# Altium schematic sheet eBox_relay.SchDoc
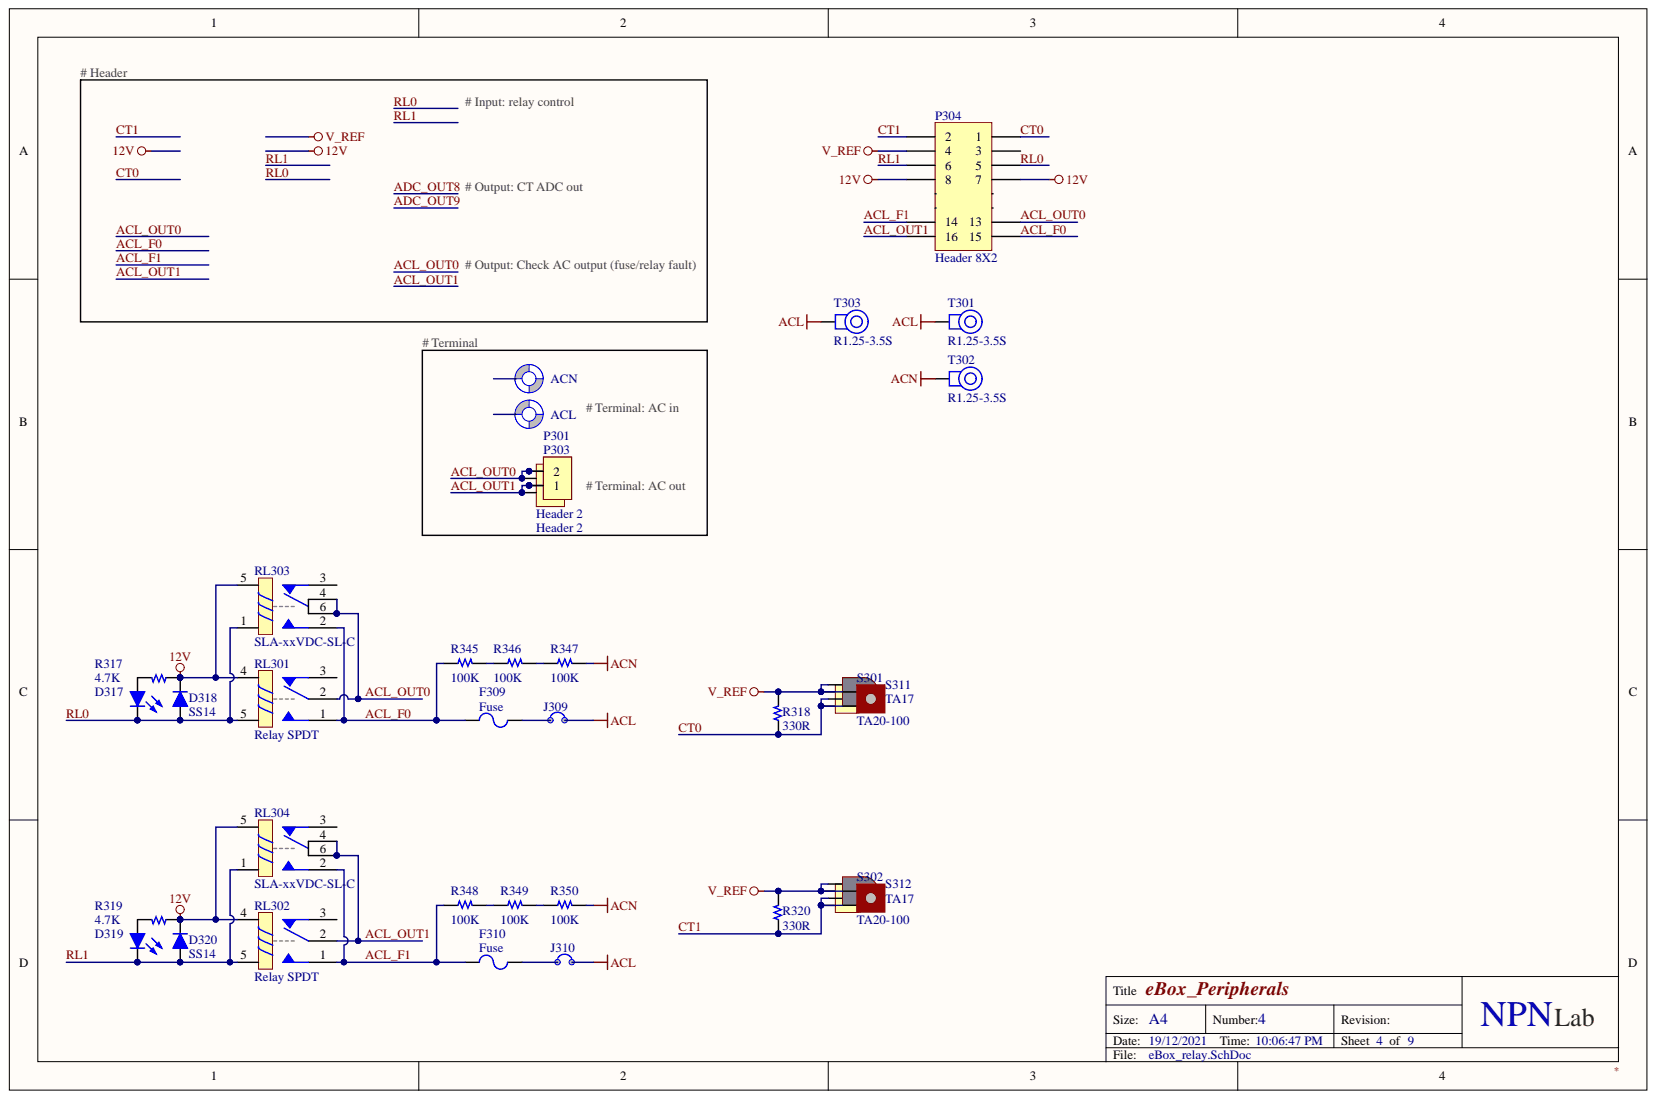
[diagram p0: eBox_relay.SchDoc]

## Parts
ACL  Screw Hole  [SCREW/3.2/7.0/M]  (value not drawn)  (no BOM)
ACN  Screw Hole  [SCREW/3.2/7.0/M]  (value not drawn)  (no BOM)
D317  Led  [LED 3MM - green]  (value not drawn)
D318  SS14  [DO-214AC (SMA) L]
D319  Led  [LED 3MM - green]  (value not drawn)
D320  SS14  [DO-214AC (SMA) L]
F309  Fuse  [FUSE 2 DIP 0520 BOX]
F310  Fuse  [FUSE 2 DIP 0520 BOX]
J309  JUMP 2 CUSTOM  [JUMP/2/Custom]  (value not drawn)  (no BOM)
J310  JUMP 2 CUSTOM  [JUMP/2/Custom]  (value not drawn)  (no BOM)
P301  Header 2  [HDR/Terminal/2/KF1000]  (no BOM)
P303  Header 2  [HDR/Terminal/2/KF55C-10]
P304  Header 8X2  [HDR/Header/16/DL/EDGE]
P305  Header 2  [HDR/Terminal/2/KF1000]  (no BOM)
R317  4.7K  [R 0603]
R318  330R  [R 0603]
R319  4.7K  [R 0603]
R320  330R  [R 0603]
R345  100K  [R 1206]
R346  100K  [R 1206]
R347  100K  [R 1206]
R348  100K  [R 1206]
R349  100K  [R 1206]
R350  100K  [R 1206]
RL301  Relay SPDT  [RL_SPDT_MIX]
RL302  Relay SPDT  [RL_SPDT_MIX]
RL303  SLA-xxVDC-SL-C  [RL_SPDT_30A]
RL304  SLA-xxVDC-SL-C  [RL_SPDT_30A]
S301  TA20-100  [TA20-100]
S302  TA20-100  [TA20-100]
S309  TA12-200  [TA12]  (value not drawn)
S310  NA  [TA12]  (value not drawn)
S311  TA17  [TA17]
S312  TA17  [TA17]
T301  R1.25-3.5S  [R1.25-3.5S]
T302  R1.25-3.5S  [R1.25-3.5S]
T303  R1.25-3.5S  [R1.25-3.5S]

## Nets
12V: D318.2 D320.2 P304.7 P304.8 R317.1 R319.1 RL301.4 RL302.4 RL303.5 RL304.5
ACL: J309.2 J310.2 T301.1 T303.1
ACN: R347.2 R350.2 T302.1
V_REF: P304.4 R318.2 R320.2 S301.3 S302.3 S309.1 S310.1 S311.1 S312.1
ACL_F0: F309.1 P304.15 R345.1 RL301.1 RL303.2
ACL_F1: F310.1 P304.14 R348.1 RL302.1 RL304.2
ACL_OUT0: P301.1 P303.1 P304.13 P305.2 RL301.2 RL303.4 RL303.6
ACL_OUT1: P301.2 P303.2 P304.16 P305.1 RL302.2 RL304.4 RL304.6
CT0: P304.1 R318.1 S301.4 S309.2 S311.2
CT1: P304.2 R320.1 S302.4 S310.2 S312.2
RL0: D317.2 D318.1 P304.5 RL301.5 RL303.1
RL1: D319.2 D320.1 P304.6 RL302.5 RL304.1
NetD317_1: D317.1 R317.2
NetD319_1: D319.1 R319.2
NetF309_2: F309.2 J309.1
NetF310_2: F310.2 J310.1
NetR345_2: R345.2 R346.1
NetR346_2: R346.2 R347.1
NetR348_2: R348.2 R349.1
NetR349_2: R349.2 R350.1
ADC_OUT8:
ADC_OUT9:

Not connected: ACL 1; ACN 1; P304 3 9 10 11 12; RL301 3; RL302 3; RL303 3; RL304 3

## Library data of the parts
D317 D319 = Led: LED RED CLEAR 0805 SMD; Component Kind: Standard; Library Name: chipfc_altium_libs.IntLib; PACKAGE: SMD0805; STOCK CODE: HT17-2102SURC; STOCK DESC: SMD-0805 High Bright Red Chip LED; SUPPLIER: tme.vn; TYPE: SMD; UNIT PRICE: 800
D318 D320 = Diode: DIODE SCHOTTKY 40V 1A SMA; BRAND: Toshiba; TYPE: SMD; Component Kind: Standard; Library Name: chipfc_altium_libs.IntLib; PACKAGE: DO-214AC; PART NO: SS14; STOCK CODE: SS14; STOCK DESC: 1A 40V Schottky Barrier Diode; SUPPLIER: tme.vn; UNIT PRICE: 1000
P301 P303 P305 = Header 2: Header, 2-Pin; Library Name: chipfc_altium_libs.IntLib
  P303: Component Kind: Standard
P304 = Header 8X2: Header, 8-Pin, Dual row; Component Kind: Standard; Library Name: chipfc_altium_libs.IntLib
R317 R319 = Resistor: RES SMD 4.7K OHM 1% 1/10W 0805; Component Kind: Standard; Library Name: chipfc_altium_libs.IntLib; PACKAGE: SMD0805; STOCK CODE: RM10JTN472; STOCK DESC: 4.7K 5% SMD-0805 Resistor; SUPPLIER: tme.vn; TYPE: SMD; UNIT PRICE: 60
R318 R320 = Resistor: RES SMD 680 OHM 1% 1/10W 0805; Component Kind: Standard; Library Name: chipfc_altium_libs.IntLib; PACKAGE: SMD0805; STOCK CODE: RM10JTN681; STOCK DESC: 680R 5% SMD-0805 Resistor; SUPPLIER: tme.vn; TYPE: SMD; UNIT PRICE: 60
R345-R350 = Resistor: RES SMD 10K OHM 5% 1/4W 1206; Component Kind: Standard; Library Name: chipfc_altium_libs.IntLib; PACKAGE: SMD1206; STOCK DESC: RES SMD 10K OHM 5% 1/4W 1206; SUPPLIER: banlinhkien.com; TYPE: SMD; UNIT PRICE: 240
RL303 RL304 = Relay SPDT_SLA: Component Kind: Standard; Description: SLA-xxVDC-SL-C; Library Name: chipfc_altium_libs.IntLib
S309 = TA12: CURR SENSE 1:2000 5A T/H; BRAND: YHDC; Component Kind: Standard; Library Name: chipfc_altium_libs.IntLib; PART NO: TA12-200; SUPPLIER: CHINA; TYPE: TH; UNIT PRICE: 22328
S310 = TA12: CURR SENSE 1:2000 5A T/H; PART NO: TA12-200; BRAND: YHDC; Component Kind: Standard; Library Name: chipfc_altium_libs.IntLib; SUPPLIER: CHINA; TYPE: TH; UNIT PRICE: 22328
ACL ACN = Screw Hole: Description: Screw Hole; Library Name: chipfc_altium_libs.IntLib; TYPE: NO-BOM; UNIT PRICE: 0
F309 F310 = Fuse: Component Kind: Standard; Description: Fuse; Library Name: chipfc_altium_libs.IntLib; UNIT PRICE: 0
J309 J310 = JUMP 2 CUSTOM: Library Name: chipfc_altium_libs.IntLib; TYPE: NO-BOM; UNIT PRICE: 0
RL301 RL302 = Relay SPDT: Component Kind: Standard; Description: Relay SPDT; Library Name: chipfc_altium_libs.IntLib
S301 S302 = TA20-100: Component Kind: Standard; Library Name: chipfc_altium_libs.IntLib
S311 S312 = TA17: Component Kind: Standard; Library Name: chipfc_altium_libs.IntLib
T301-T303 = R1.25-3.5S: Component Kind: Standard; Description: R1.25-3.5S; Library Name: chipfc_altium_libs.IntLib
